# Altium schematic sheet sd_card.SchDoc(sd_card)
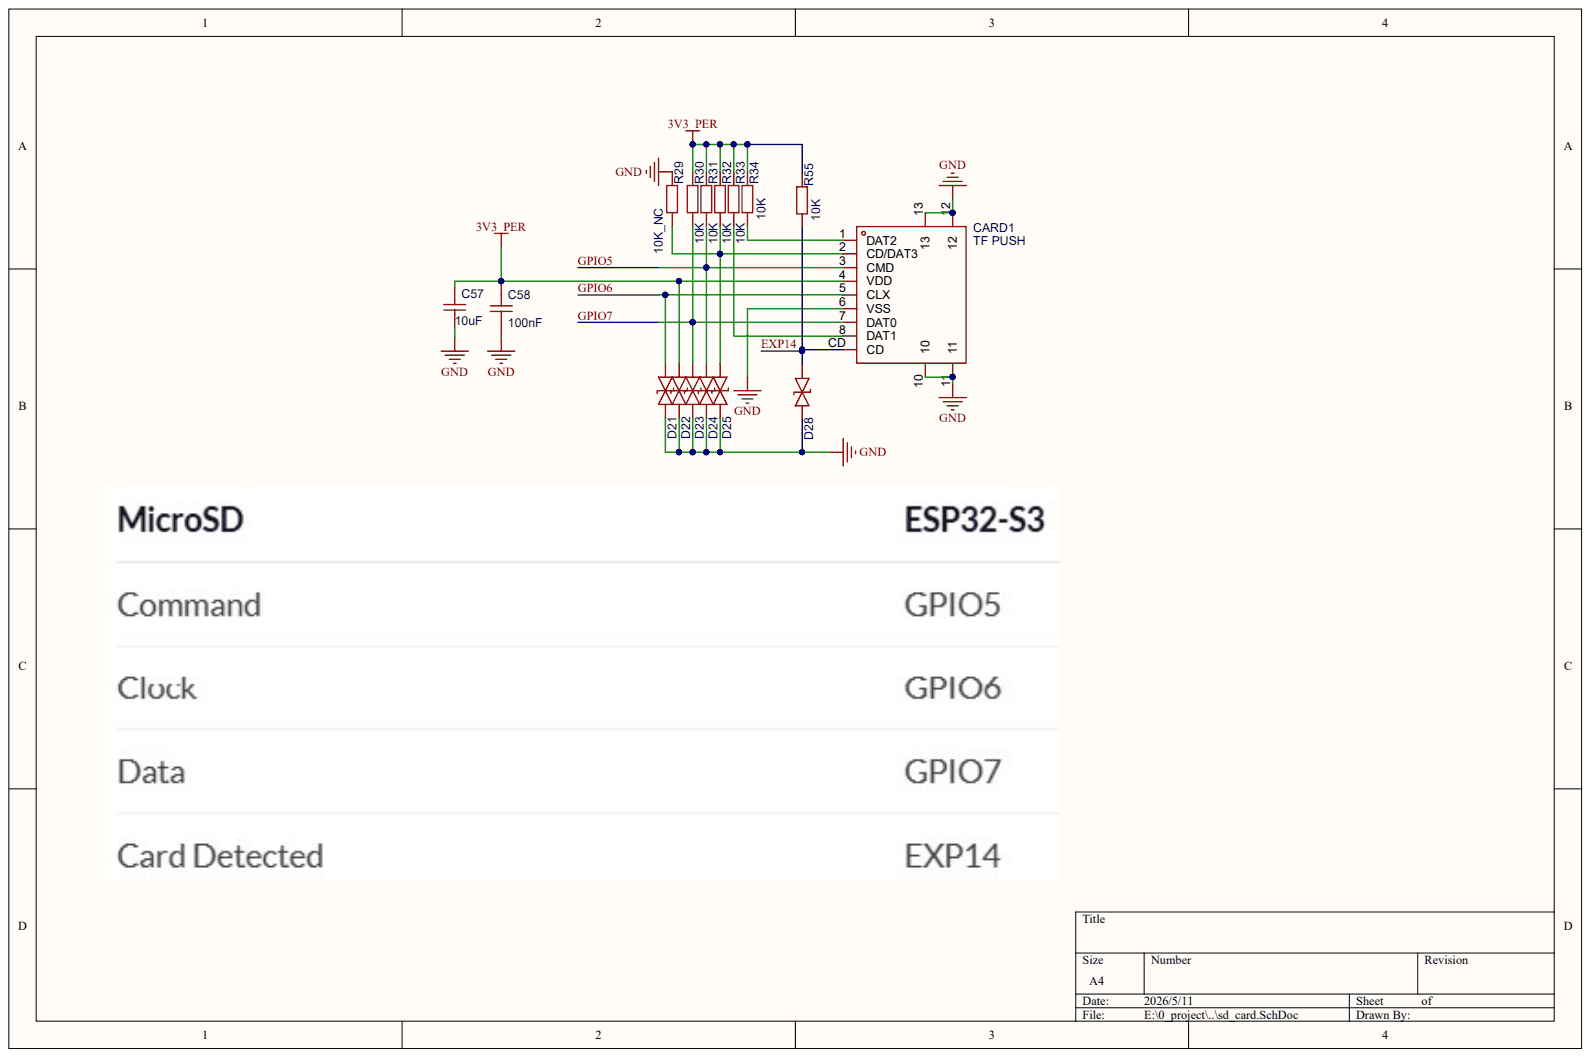
[diagram p0: sd_card.SchDoc]

## Parts
C57  10uF
C58  100nF
CARD1  TF PUSH
D21  LESD8D3.3CAT5G  (value not drawn)
D22  LESD8D3.3CAT5G  (value not drawn)
D23  LESD8D3.3CAT5G  (value not drawn)
D24  LESD8D3.3CAT5G  (value not drawn)
D25  LESD8D3.3CAT5G  (value not drawn)
D28  LESD8D3.3CAT5G  (value not drawn)
R29  10K_NC
R30  10K
R31  10K
R32  10K
R33  10K
R34  10K
R55  10K

## Nets
3V3_PER: C57.2 C58.2 CARD1.4(VDD) D22.2 R30.2 R31.2 R32.2 R33.2 R34.2 R55.2
GND: C57.1 C58.1 CARD1.6(VSS) CARD1.10 CARD1.11 CARD1.12 CARD1.13 D21.1 D22.1 D23.1 D24.1 D25.1 D28.1 R29.2
EXP14: CARD1.CD D28.2 R55.1
GPIO5: CARD1.3(CMD) D24.2 R31.1
GPIO6: CARD1.5(CLX) D21.2
GPIO7: CARD1.7(DAT0) D23.2 R30.1
NetCARD1_1: CARD1.1(DAT2) R34.1
NetCARD1_2: CARD1.2(CD/DAT3) D25.2 R29.1 R32.1
NetCARD1_8: CARD1.8(DAT1) R33.1

## Library data of the parts
C57 = (no symbol name): Manufacturer Part: CL05A106MQ5NUNC; AddInBom: yes; ModelId: 36a51122f27c49738f86b3bfa98863e0; ModelName: C0402_L1.0-W0.5-H0.5; ModelTransform: 39.37,19.685,0,0,0,0,0,0,0; Voltage Rated: 6.3V; Temperature Coefficient: X5R; Supplier Part: C15525; JLCPCB Part Class: Basic Part; Supplier: LCSC; Value: 10uF; Symbol: CL05A106MQ5NUNC; Device: CL05A106MQ5NUNC; Supplier Footprint: 0402; Datasheet: https://atta.szlcsc.com/upload/public/pdf/source/20220823/2B02EE04F8F211D38A52DCB16EB6BEBA.pdf; ConvertToPcb: yes; FootprintName: C0402
C58 = (no symbol name): Symbol: CL05B104KO5NNNC; AddInBom: yes; ModelId: 36a51122f27c49738f86b3bfa98863e0; ModelName: C0402_L1.0-W0.5-H0.5; ModelTransform: 39.37,19.685,0,0,0,0,0,0,0; Voltage Rated: 16V; Temperature Coefficient: X7R; Device: CL05B104KO5NNNC; SupplierPart: C1525; ManufacturerPart: CL05B104KO5NNNC; Supplier Footprint: 0402; JLCPCB Part Class: Basic Part; Datasheet: https://atta.szlcsc.com/upload/public/pdf/source/20160218/1457707763339.pdf; Supplier: LCSC; ConvertToPcb: yes; FootprintName: C0402; Value: 100nF
CARD1 = (no symbol name): AddInBom: yes; ModelId: 2d6270e77c9a43c6bb0aca962ba811a2; ModelName: TF-SMD_TF-PUSH; ModelTransform: 635.826,598.424,0,0,0,0,0.002,-82.441,-23.622; Maximum Body Height: 2mm; Symbol: TF PUSH; Device: TF PUSH; SupplierPart: C393941; ManufacturerPart: TF PUSH; Supplier Footprint: SMD; Datasheet: https://atta.szlcsc.com/upload/public/pdf/source/20191011/C393941_0DDD896EE907FEB750D8B7B8982E2A67.pdf; Supplier: LCSC; ConvertToPcb: yes; FootprintName: TF-SMD_TF-PUSH; Supplementary Features: -
D21-D25 D28 = (no symbol name): Device: LESD8D3.3CAT5G_C172409; AddInBom: yes; ModelId: 072dcf228994456dbe83693440270b5f; ModelName: WBFBP-03E_L1.0-W0.6-P0.64-BL; ModelTransform: 40.1574,23.622,0,0,0,0,0,0,0; Reverse Stand-Off Voltage (Vrwm): 3.3V; Maximum Clamping Voltage: 10V; Peak Pulse Current (Ipp)@10/1000us: 6A; Breakdown Voltage: 5V; Type: ESD; Symbol: LESD8D3.3CAT5G; SupplierPart: C172409; ManufacturerPart: LESD8D3.3CAT5G; Supplier Footprint: SOD-882; Datasheet: https://atta.szlcsc.com/upload/public/pdf/source/20180130/C172409_15172974211921323438.pdf; Supplier: LCSC; ConvertToPcb: yes; FootprintName: SOD-882_L1.0-W0.6-BI
R29 = (no symbol name): Device: 0402WGF5102TCE; AddInBom: yes; ModelId: 671aefced39d4c318694bba8bd8efd39; ModelName: R0402_L1.0-W0.5-H0.5; ModelTransform: 39.37,19.685,0,0,0,0,0,0.0005,0; Power(Watts): 62.5mW; Overload Voltage (Max): 50V; Value: 10K_NC; ManufacturerPart: 0402WGF5102TCE; Supplier Footprint: 0402; JLCPCB Part Class: Basic Part; Datasheet: https://atta.szlcsc.com/upload/public/pdf/source/20200306/C422600_1E6D84923E4A46A82E41ADD87F860B5C.pdf; Supplier: LCSC; ConvertToPcb: yes; FootprintName: R0402
R30-R34 R55 = (no symbol name): 3D Model: 98c88bc2b9094f218f3a3e30a7012738; 3D Model Transform: 39.37,19.685,0,0,0,0,0,0,0; Device: 0402WGF1002TCE; AddInBom: yes; ModelName: R0402_L1.0-W0.5-H0.4; Power(Watts): 62.5mW; Overload Voltage (Max): 50V; JLCPCB Part Class: Basic Part; SupplierPart: C25744; ManufacturerPart: 0402WGF1002TCE; Supplier Footprint: 0402; Datasheet: https://atta.szlcsc.com/upload/public/pdf/source/20200306/C422600_1E6D84923E4A46A82E41ADD87F860B5C.pdf; Supplier: LCSC; ConvertToPcb: yes; FootprintName: R0402; Value: 10K
